# Altium schematic sheet OnePhaseController.SchDoc
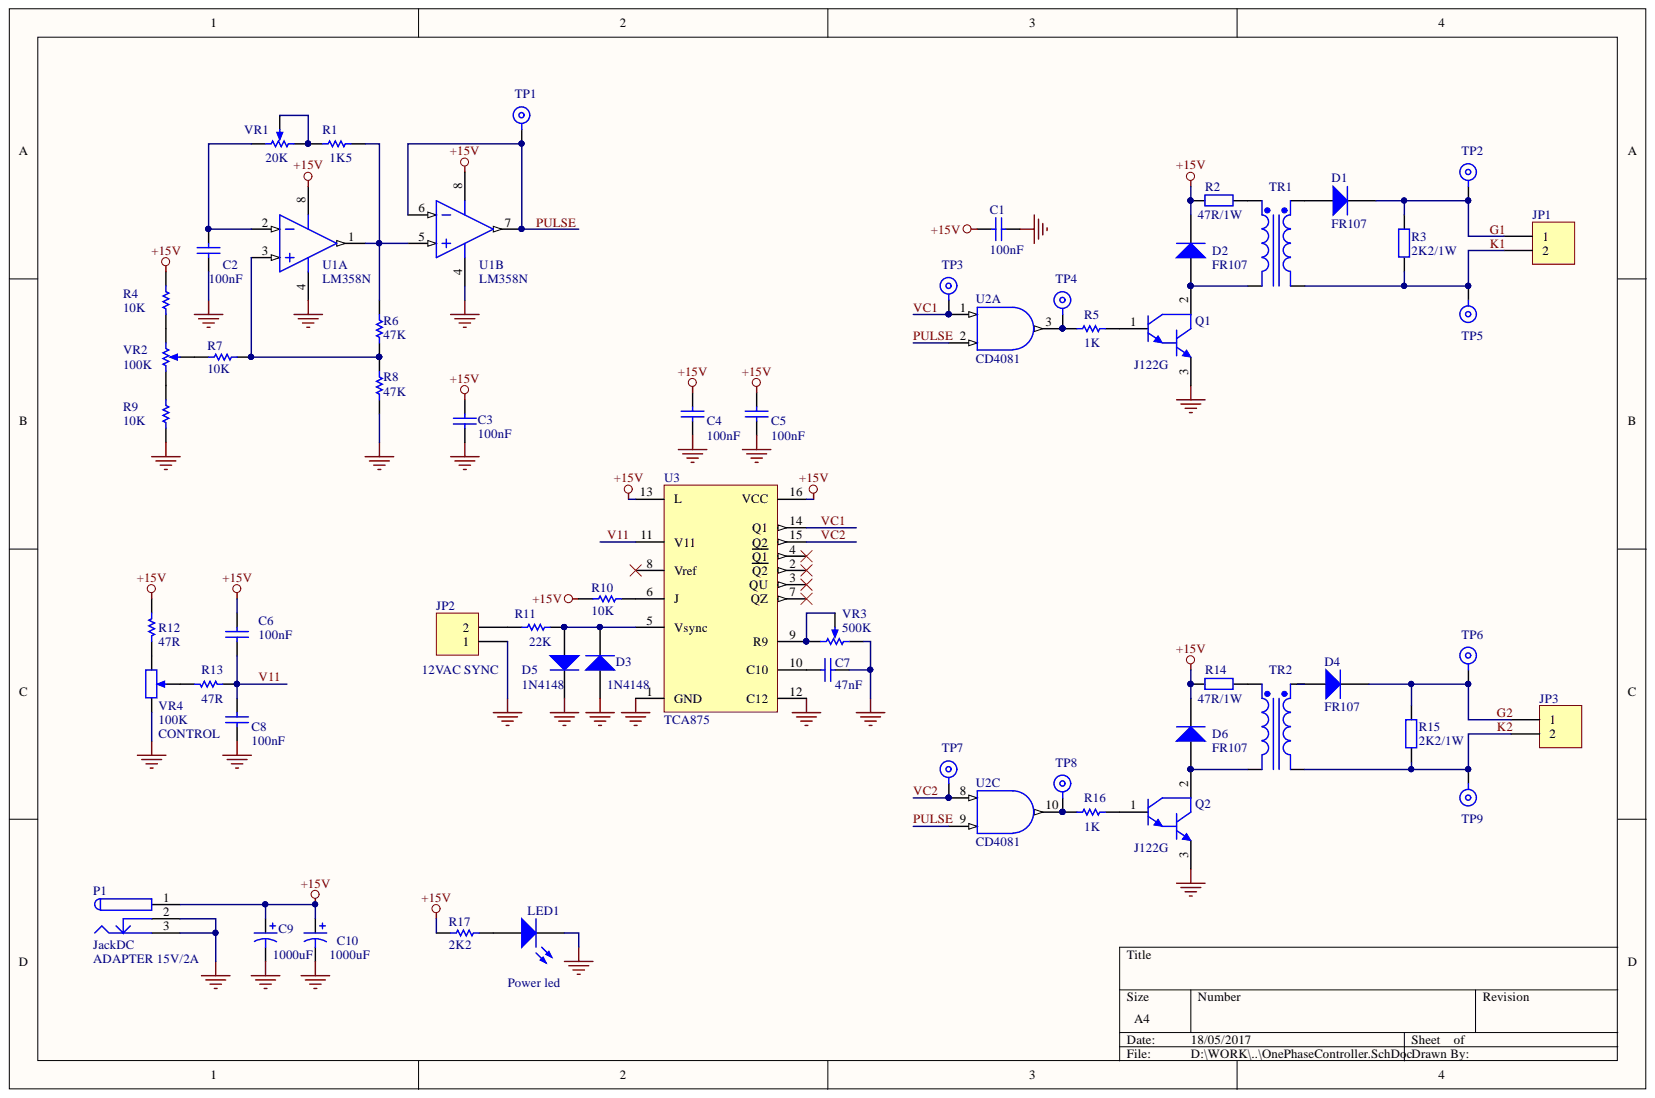
[diagram p0: OnePhaseController.SchDoc]

## Parts
C1  value 100nF  [C-0805]  (hidden comment: 104)
C2  value 100nF  [C-0805]  (hidden comment: 104)
C3  value 100nF  [C-0805]  (hidden comment: 104)
C4  value 100nF  [C-0805]  (hidden comment: 104)
C5  value 100nF  [C-0805]  (hidden comment: 104)
C6  value 100nF  [C-0805]  (hidden comment: 104)
C7  value 47nF  [C-200]  (hidden comment: 473)
C8  value 100nF  [C-0805]  (hidden comment: 104)
C9  value 1000uF  [C-13x25mm]  (hidden comment: 1000uF/25V)
C10  value 1000uF  [C-13x25mm]  (hidden comment: 1000uF/25V)
D1  FR107  [D-DO214-AC(SMA)]
D2  FR107  [D-DO214-AC(SMA)]
D3  1N4148  [D-SOD-80]
D4  FR107  [D-DO214-AC(SMA)]
D5  1N4148  [D-SOD-80]
D6  FR107  [D-DO214-AC(SMA)]
JP1  KF301  [TER-KF301-2]  (value not drawn)
JP2  value 12VAC SYNC  [TER-KF301-2]  (hidden comment: KF301)
JP3  KF301  [TER-KF301-2]  (value not drawn)
LED1  value Power led  [LED-D5-2A]  (hidden comment: RED)
P1  JackDC  value ADAPTER 15V/2A  [TER-JACKDC-5.5x2.1mm]
Q1  J122G  [TO-252-3]
Q2  J122G  [TO-252-3]
R1  1K5  [R-0805]
R2  47R/1W  [R-1W-P200mil]
R3  2K2/1W  [R-1W-P200mil]
R4  10K  [R-0805]
R5  1K  [R-0805]
R6  47K  [R-0805]
R7  10K  [R-0805]
R8  47K  [R-0805]
R9  10K  [R-0805]
R10  10K  [R-0805]
R11  22K  [R-0805]
R12  47R  [R-0805]
R13  47R  [R-0805]
R14  47R/1W  [R-1W-P200mil]
R15  2K2/1W  [R-1W-P200mil]
R16  1K  [R-0805]
R17  2K2  [R-0805]
TP1  Testpoint  [TP1A]  (value not drawn)
TP2  Testpoint  [TP1A]  (value not drawn)
TP3  Testpoint  [TP1A]  (value not drawn)
TP4  Testpoint  [TP1A]  (value not drawn)
TP5  Testpoint  [TP1A]  (value not drawn)
TP6  Testpoint  [TP1A]  (value not drawn)
TP7  Testpoint  [TP1A]  (value not drawn)
TP8  Testpoint  [TP1A]  (value not drawn)
TP9  Testpoint  [TP1A]  (value not drawn)
TR1  BIENAPCACHLY  [TRANS-DRIVER-THYR]  (value not drawn)
TR2  BIENAPCACHLY  [TRANS-DRIVER-THYR]  (value not drawn)
U1  LM358N  [SOP-8]  (parts A,B)
U2  value CD4081  [SOP-14]  (hidden comment: HCF4081BM1)  (parts A,C)
U3  TCA875  [SK-DIP16-300]
VR1  20K  [VR-3296W]
VR2  100K  [VR-3296W]
VR3  500K  [VR-3296W]
VR4  100K  [TER-KF301-3]

## Nets
+15V: C1.2 C3.2 C4.2 C5.2 C6.2 C9.1 C10.1 D2.2 D6.2 P1.1 R2.1 R4.2 R10.2 R12.2 R14.1 R17.1 U1.8 U2.14 U3.13(L) U3.16(VCC)
GND: C1.1 C2.2 C3.1 C4.1 C5.1 C7.2 C8.1 C9.2 C10.2 D3.1 D5.2 JP2.1 LED1.2 P1.2 P1.3 Q1.3 Q2.3 R8.2 R9.1 U1.4 U2.7 U3.1(GND) U3.12(C12) VR3.1 VR4.3
G1: D1.2 JP1.1 R3.1 TP2.1
G2: D4.2 JP3.1 R15.1 TP6.1
K1: JP1.2 R3.2 TP5.1 TR1.3
K2: JP3.2 R15.2 TP9.1 TR2.3
PULSE: TP1.1 U1.6 U1.7 U2.2 U2.9
V11: C6.1 C8.2 R13.1 U3.11(V11)
VC1: TP3.1 U2.1 U3.14(Q1)
VC2: TP7.1 U2.8 U3.15(Q2)
NetC2_1: C2.1 U1.2 VR1.3
NetC7_1: C7.1 U3.10(C10)
NetD1_1: D1.1 TR1.4
NetD2_1: D2.1 Q1.2 TR1.2
NetD3_2: D3.2 D5.1 R11.1 U3.5(Vsync)
NetD4_1: D4.1 TR2.4
NetD6_1: D6.1 Q2.2 TR2.2
NetJP2_2: JP2.2 R11.2
NetLED1_1: LED1.1 R17.2
NetQ1_1: Q1.1 R5.1
NetQ2_1: Q2.1 R16.1
NetR1_1: R1.1 R6.1 U1.1 U1.5
NetR1_2: R1.2 VR1.1 VR1.2
NetR2_2: R2.2 TR1.1
NetR4_1: R4.1 VR2.3
NetR5_2: R5.2 TP4.1 U2.3
NetR6_2: R6.2 R7.1 R8.1 U1.3
NetR7_2: R7.2 VR2.2
NetR9_2: R9.2 VR2.1
NetR10_1: R10.1 U3.6(J)
NetR12_1: R12.1 VR4.1
NetR13_2: R13.2 VR4.2
NetR14_2: R14.2 TR2.1
NetR16_2: R16.2 TP8.1 U2.10
NetU3_9: U3.9(R9) VR3.2 VR3.3

Not connected: U3 2(Q2) 3(QU) 4(Q1) 7(QZ) 8(Vref)

## Library data of the parts
C1 C3-C6 C8 = Cap: Capacitor; Component Kind: Standard; LatestRevisionDate: 17-Jul-2002; LatestRevisionNote: Re-released for DXP Platform.; Library Name: Miscellaneous Devices.IntLib; PackageReference: RAD-0.3; Published: 8-Jun-2000; Signal Integrity: Cap; Simulation: CAP; Publisher: Altium Limited
C2 C7 = Cap: Capacitor; Manufacturer: Generic Components; Class: Passive; Component Kind: Standard; Library Name: Miscellaneous Devices.IntLib; Published: 08/06/2000; Signal Integrity: Cap; Simulation: CAP; SubClass: Capacitor; Publisher: Altium Hobart Technology Centre
C9 C10 = Cap Pol1: Polarized Capacitor (Radial); Component Kind: Standard; LatestRevisionDate: 17-Jul-2002; LatestRevisionNote: Re-released for DXP Platform.; Library Name: Miscellaneous Devices.IntLib; PackageReference: RB7.6-15; Published: 8-Jun-2000; Signal Integrity: Cap Pol; Simulation: CAP; Publisher: Altium Limited
D1-D6 = Diode: Default Diode; Manufacturer: Generic Components; Class: Discrete; SubClass: Diode - Switching; Component Kind: Standard; Library Name: Miscellaneous Devices.IntLib; Published: 08/06/2000; Signal Integrity: Diode; Simulation: DIODE; Publisher: Altium Hobart Technology Centre
  D1 D2 D4 D6: Value: FR107
  D3 D5: Value: 1N4148
JP1-JP3 = Header 2: Header, 2-Pin; Manufacturer: Generic Components; Class: Connector; Component Kind: Standard; Library Name: Miscellaneous Connectors.IntLib; Signal Integrity: Connector
  JP1 JP3: Value: Header 2
LED1 = LED1: Typical RED GaAs LED; Component Kind: Standard; LatestRevisionDate: 08-Jul-2005; LatestRevisionNote: Mech Layer 1 removed.; Library Name: Miscellaneous Devices.IntLib; PackageReference: LED-1; Published: 8-Jun-2000; Simulation: LED1; Publisher: Altium Limited
P1 = JackDC: Low Voltage Power Supply Connector; Component Kind: Standard; ComponentLink1Description: Manufacturer Link; ComponentLink1URL: http://domino.kycon.com/internet/Products.html; DatasheetDocument: 09-May-2002; Published: 17-Dec-2003; Signal Integrity: Connector; Library Name: Dlib.SCHLIB
Q1 Q2 = NPN1: NPN Darlington Bipolar Transistor; Code_JEDEC: TO-92; Component Kind: Standard; DatasheetVersion: 1989; LatestRevisionDate: 17-Jul-2002; LatestRevisionNote: Re-released for DXP Platform.; Library Name: Miscellaneous Devices.IntLib; PackageDescription: TO, Flat Index; 3 In-Line, Axial Leads; Body Dia. 4.6mm; Leads 0.41 x 0.39 mm (max); PackageReference: TO-92; PackageVersion: 1989; Published: 8-Jun-2000; Signal Integrity: NPN; Simulation: NPN1; Value: J122G
R1 R4-R13 R16 R17 = Res1: Resistor; Component Kind: Standard; LatestRevisionDate: 17-Jul-2002; LatestRevisionNote: Re-released for DXP Platform.; Library Name: Miscellaneous Devices.IntLib; PackageReference: AXIAL-0.3; Published: 8-Jun-2000; Signal Integrity: Res; Simulation: RESISTOR; Publisher: Altium Limited
  R1: Value: 1K5
  R4 R7 R9 R10: Value: 10K
  R5 R16: Value: 1K
  R6 R8: Value: 47K
  R11: Value: 22K
  R12 R13: Value: 47R
  R17: Value: 2K2
R2 R3 R14 R15 = Res2: Resistor; Manufacturer: Generic Components; Class: Passive; Component Kind: Standard; Library Name: Miscellaneous Devices.IntLib; Published: 08/06/2000; Signal Integrity: Res2; Simulation: RESISTOR; SubClass: Resistor; Publisher: Altium Hobart Technology Centre
  R2 R14: Value: 47R/1W
  R3 R15: Value: 2K2/1W
TP1-TP9 = TP1: Component Kind: Standard; Library Name: DEVICE.SCHLIB
TR1 TR2 = BIENAPCACHLY: value: TRANFORMER; Component Kind: Standard; Library Name: MAKESCH.Lib
U1 = LM358N: Low-Power Dual Operational Amplifier; Component Kind: Standard; ComponentLink1Description: Manufacturer Link; ComponentLink1URL: http://www.national.com/; ComponentLink2Description: Datasheet; ComponentLink2URL: http://www.national.com/ds.cgi/LM/LM158.pdf; ComponentLink3Description: URL Link1; ComponentLink3URL: http://www.national.com/pf/LM/LM358.html; DatasheetVersion: Sep-1999; LatestRevisionDate: 06-Jun-2005; LatestRevisionNote: Stylized 3D Model Added.; Library Name: NSC Operational Amplifier.IntLib; PackageDescription: DIP; 8 Leads; Row Spacing 7.62 mm; Pitch 2.54 mm; PackageReference: N08E; PackageVersion: Sep-1997; PCB3D: N08E; Published: 15-Apr-2002; Simulation: LM358_NSC; value: LM358N; Publisher: Altium Limited
U2 = HCF4081BM1: Quad 2-Input AND-Gate; Manufacturer: ST-Microelectronics; Class: Logic; Revised: 29-Sep-2000: Name of VCC pin changed to VDD; SubClass: Gate; Component Kind: Standard; Library Name: ST Logic Gate.IntLib; Published: 08/06/2000; Simulation: HCF4081; Publisher: Altium Hobart Technology Centre
U3 = TCA785: Component Kind: Standard; Value: TCA875; Library Name: MAKESCH.Lib
VR1-VR3 = VR: Component Kind: Standard; Library Name: DEVICE.SCHLIB
  VR1: Value: 20K
  VR2: Value: 100K
  VR3: Value: 500K
VR4 = RPot2: Potentiometer; Manufacturer: Generic Components; Class: Passive; Note: CONTROL; Set Position: 0.5; Component Kind: Standard; Library Name: Miscellaneous Devices.IntLib; Published: 08/06/2000; Simulation: POT; Value: 100K; Publisher: Altium Hobart Technology Centre
